# Altium schematic sheet Gecko.SchDoc
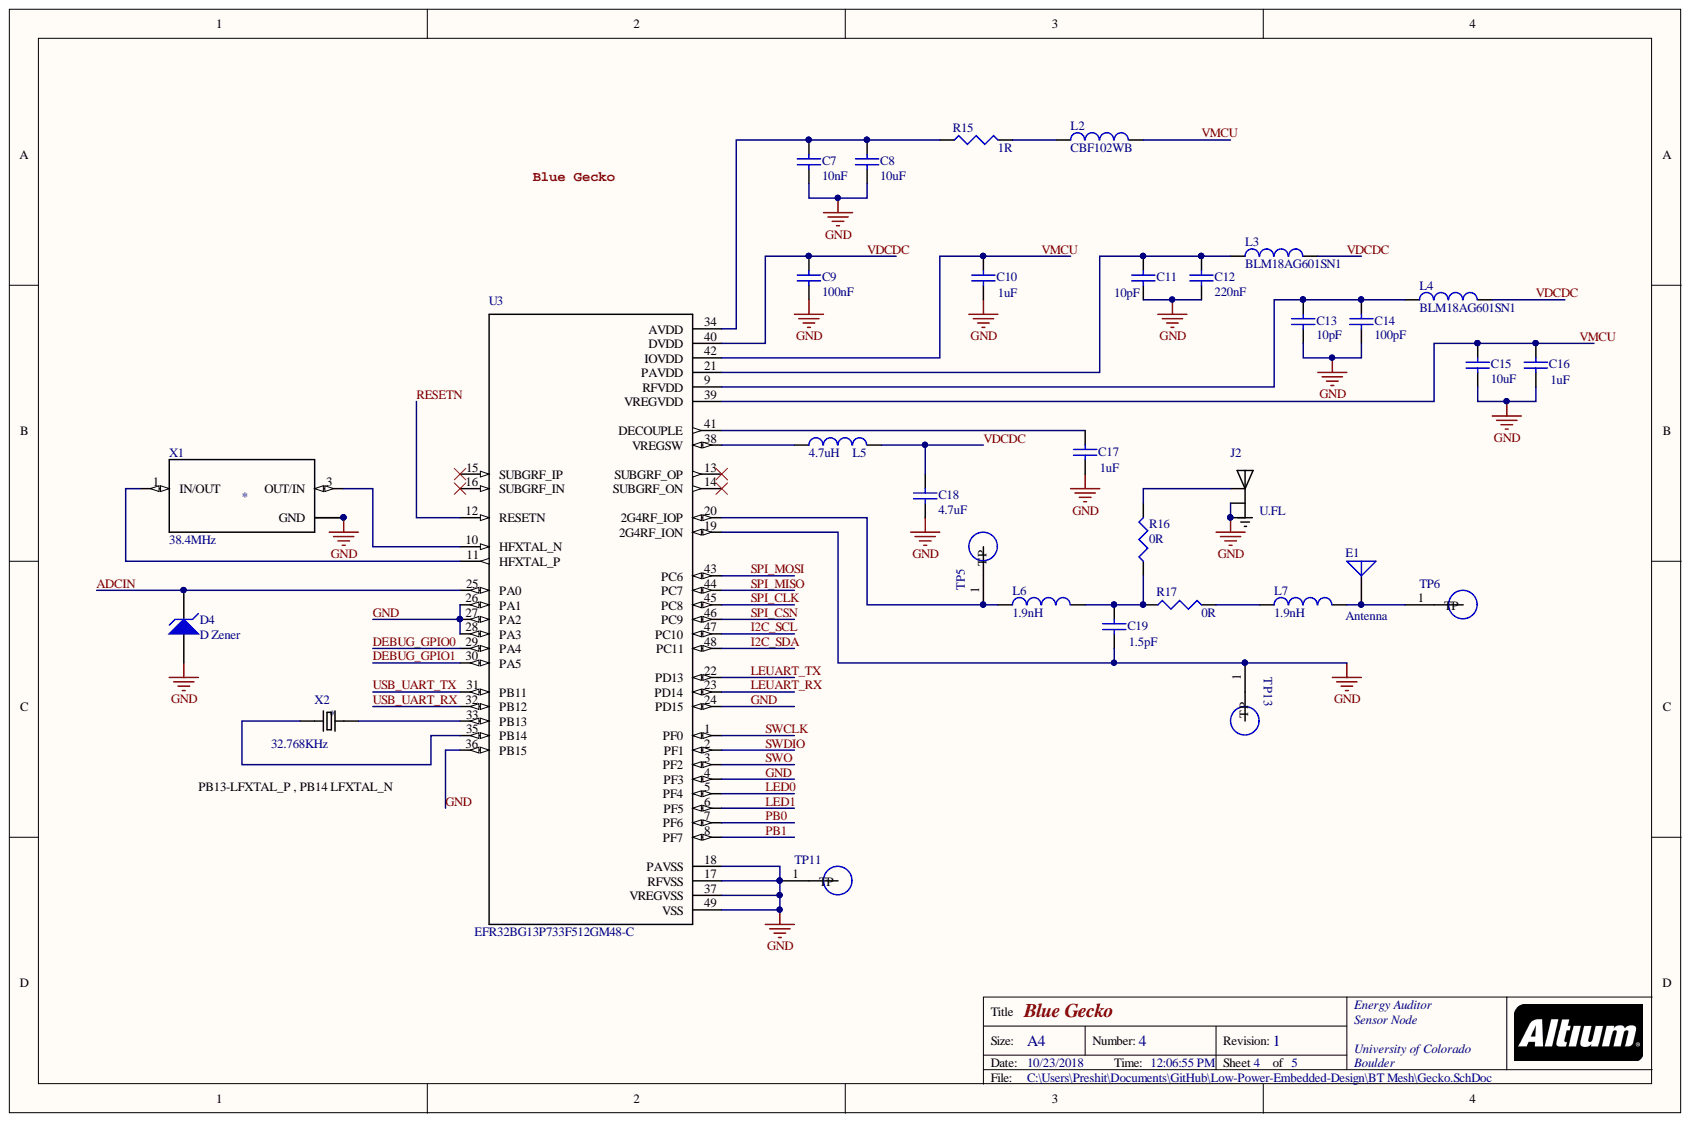
[diagram p0: Gecko.SchDoc]

## Parts
C7  10nF  [1608[0603]]
C8  10uF  [1608[0603]]
C9  100nF  [1608[0603]]
C10  1uF  [1608[0603]]
C11  10pF  [1608[0603]]
C12  220nF  [1608[0603]]
C13  10pF  [1608[0603]]
C14  100pF  [1608[0603]]
C15  10uF  [1608[0603]]
C16  1uF  [1608[0603]]
C17  1uF  [1608[0603]]
C18  4.7uF  [1608[0603]]
C19  1.5pF  [0402]
D4  D Zener  [0402]
E1  Antenna  [PIN1]
J2  U.FL  [HRS_U.FL-R-SMT-1(10)]
L2  CBF102WB  [0603]
L3  BLM18AG601SN1  [0603]
L4  BLM18AG601SN1  [0603]
L5  4.7uH  [0402-A]
L6  1.9nH  [0402-A]
L7  1.9nH  [0402-A]
R15  1R  [0402]
R16  0R  [1608[0603]]
R17  0R  [1608[0603]]
TP5  5019  [TP380X203]  (value not drawn)
TP6  5019  [TP380X203]  (value not drawn)
TP11  5019  [TP380X203]  (value not drawn)
TP13  5019  [TP380X203]  (value not drawn)
U3  EFR32BG13P733F512GM48-C  [QFN50P700X700X90-49N]
X1  38.4MHz  [XTAL_ECS-384-8-36-CKY-TR]
X2  32.768KHz  [XTAL_ECS-.327-12.5-34R-C-TR]

## Nets
ADCIN: D4.2 U3.25(PA0)
DEBUG_GPIO0: U3.29(PA4)
DEBUG_GPIO1: U3.30(PA5)
GND: C7.2 C8.2 C9.2 C10.1 C11.2 C12.2 C13.2 C14.2 C15.2 C16.1 C17.1 C18.2 C19.1 D4.1 J2.1 J2.3 TP11.1 TP13.1 U3.4(PF3) U3.17(RFVSS) U3.18(PAVSS) U3.19(2G4RF_ION) U3.24(PD15) U3.26(PA1) U3.27(PA2) U3.28(PA3) U3.36(PB15) U3.37(VREGVSS) U3.49(VSS) X1.2(GND) X1.4
I2C_SCL: U3.47(PC10)
I2C_SDA: U3.48(PC11)
LED0: U3.5(PF4)
LED1: U3.6(PF5)
LEUART_RX: U3.23(PD14)
LEUART_TX: U3.22(PD13)
PB0: U3.7(PF6)
PB1: U3.8(PF7)
RESETN: U3.12(RESETN)
SPI_CLK: U3.45(PC8)
SPI_CSN: U3.46(PC9)
SPI_MISO: U3.44(PC7)
SPI_MOSI: U3.43(PC6)
SWCLK: U3.1(PF0)
SWDIO: U3.2(PF1)
SWO: U3.3(PF2)
USB_UART_RX: U3.32(PB12)
USB_UART_TX: U3.31(PB11)
VDCDC: C9.1 C18.1 L3.2 L4.2 L5.2 U3.40(DVDD)
VMCU: C10.2 C15.1 C16.2 L2.2 U3.39(VREGVDD) U3.42(IOVDD)
NetC7_1: C7.1 C8.1 R15.1 U3.34(AVDD)
NetC11_1: C11.1 C12.1 L3.1 U3.21(PAVDD)
NetC13_1: C13.1 C14.1 L4.1 U3.9(RFVDD)
NetC17_2: C17.2 U3.41(DECOUPLE)
NetC19_2: C19.2 L6.2 R16.1 R17.1
NetE1_1: E1.1 L7.2 TP6.1
NetJ2_2: J2.2 R16.2
NetL2_1: L2.1 R15.2
NetL5_1: L5.1 U3.38(VREGSW)
NetL6_1: L6.1 TP5.1 U3.20(2G4RF_IOP)
NetL7_1: L7.1 R17.2
NetU3_10: U3.10(HFXTAL_N) X1.3(OUT/IN)
NetU3_11: U3.11(HFXTAL_P) X1.1(IN/OUT)
NetU3_33: U3.33(PB13) X2.2
NetU3_35: U3.35(PB14) X2.1

Not connected: U3 13(SUBGRF_OP) 14(SUBGRF_ON) 15(SUBGRF_IP) 16(SUBGRF_IN)

## Library data of the parts
C7 = Cap: CAP CER 10000PF 16V X7R 0603; Manufacturer: KEMET; Manufacturer Part Number: C0603S103K4RACTU; Component Kind: Standard; ComponentLink1Description: Digi-Key Link; ComponentLink1URL: https://www.digikey.com/product-detail/en/kemet/C0603S103K4RACTU/399-9135-1-ND/3522653; Digi-Key Part Number: 399-9135-1-ND; Library Name: Miscellaneous Devices.IntLib; Signal Integrity: Cap; Simulation: CAP; Value: 10nF
C8 C15 = Cap: CAP CER 10UF 10V X5R 0603; Manufacturer: Murata Electronics North America; Manufacturer Part Number: GRM188R61A106KE69D; Component Kind: Standard; ComponentLink1Description: Digi-Key Link; ComponentLink1URL: https://www.digikey.com/products/en?keywords=490-10474-1-ND; Digi-Key Part Number: 490-10474-1-ND; Library Name: Miscellaneous Devices.IntLib; Signal Integrity: Cap; Simulation: CAP; Value: 10uF
C9 C14 = Cap: CAP CER 0.1UF 16V X7R 0603; Manufacturer: AVX Corporation; Manufacturer Part Number: 0603YC104MAT4A; Component Kind: Standard; ComponentLink1Description: Digi-Key Link; Digi-Key Part Number: 478-7617-1-ND; Library Name: Miscellaneous Devices.IntLib; Signal Integrity: Cap; Simulation: CAP
  C9: ComponentLink1URL: https://www.digikey.com/products/en/capacitors/ceramic-capacitors/60?k=capacitor&k=&pkeyword=capacitor&pv2049=u0.1%C2%B5F&sf=0&FV=c0005%2C1c0002%2C380009%2C400005%2C1f140000%2Cffe0003c&quantity=&ColumnSort=0&page=1&stock=1&pageSize=25; Value: 100nF
  C14: ComponentLink1URL: https://www.digikey.com/products/en/capacitors/ceramic-capacitors/60?k=capacitor&k=&pkeyword=capacitor&pv2049=u0.1%C2%B5F&sf=0&FV=c0005%2C1c0002%2C380009%2C400005%2C440005%2C1f140000%2Cffe0003c&quantity=&ColumnSort=0&page=1&stock=1&pageSize=25; Value: 100pF
C10 C16 C17 = Cap: CAP CER 1UF 16V X7R 0603; Manufacturer: Samsung Electro-Mechanics; Manufacturer Part Number: CL10B105MO8NNWC; Component Kind: Standard; ComponentLink1Description: Digi-Key Link; ComponentLink1URL: https://www.digikey.com/product-detail/en/samsung-electro-mechanics/CL10B105MO8NNWC/1276-6524-1-ND/5961383; Digi-Key Part Number: 1276-6524-1-ND; Library Name: Miscellaneous Devices.IntLib; Signal Integrity: Cap; Simulation: CAP; Value: 1uF
C11 C13 = Cap: CAP CER 10PF 50V C0G/NP0 0603; Manufacturer: Johanson Dielectrics Inc.; Manufacturer Part Number: 500X14N100MV4T; Component Kind: Standard; ComponentLink1Description: Digi-Key Link; ComponentLink1URL: https://www.digikey.com/products/en/capacitors/ceramic-capacitors/60?k=capacitor&k=&pkeyword=capacitor&pv2049=u10pF&pv17=18&sf=0&FV=c0005%2C1c0002%2C400005%2C1f140000%2Cffe0003c&quantity=&ColumnSort=0&page=1&stock=1&pageSize=25; Digi-Key Part Number: 709-1001-1-ND; Library Name: Miscellaneous Devices.IntLib; Signal Integrity: Cap; Simulation: CAP; Value: 10pF
C12 = Cap: CAP CER 0.22UF 16V X7R 0603; Manufacturer: TDK Corporation; Manufacturer Part Number: CGA3E2X7R1C224M080AD; Component Kind: Standard; ComponentLink1Description: Digi-Key Link; ComponentLink1URL: https://www.digikey.com/product-detail/en/tdk-corporation/CGA3E2X7R1C224M080AD/445-9810-1-ND/3931737; Digi-Key Part Number: 445-9810-1-ND; Library Name: Miscellaneous Devices.IntLib; Signal Integrity: Cap; Simulation: CAP; Value: 220nF
C18 = Cap: CAP CER 4.7UF 16V X5R 0603; Manufacturer: Taiyo Yuden; Manufacturer Part Number: EMK107ABJ475MA-T; Component Kind: Standard; ComponentLink1Description: Digi-Key Link; ComponentLink1URL: https://www.digikey.com/product-detail/en/taiyo-yuden/EMK107ABJ475MA-T/587-6003-1-ND/8040666; Digi-Key Part Number: 587-6003-1-ND; Library Name: Miscellaneous Devices.IntLib; Signal Integrity: Cap; Simulation: CAP; Value: 4.7uF
C19 = Cap: CAP CER 1.5PF 50V C0G/NP0 0402; Manufacturer: Murata Electronics North America; Manufacturer Part Number: GRM1555C1H1R5WA01D; Component Kind: Standard; ComponentLink1Description: Digi-Key Link; ComponentLink1URL: https://www.digikey.com/product-detail/en/murata-electronics-north-america/GRM1555C1H1R5WA01D/490-6213-1-ND/3845410; Digi-Key Part Number: 490-6213-1-ND; Library Name: Miscellaneous Devices.IntLib; Signal Integrity: Cap; Simulation: CAP; Value: 1.5pF
D4 = D Zener: Zener Diode; Manufacturer: Texas Instruments; Manufacturer Part Number: TPD1E10B06DPYR; Component Kind: Standard; ComponentLink1Description: Digi-Key Link; ComponentLink1URL: https://www.digikey.com/product-detail/en/texas-instruments/TPD1E10B06DPYR/296-30406-1-ND/3283560; Digi-Key Part Number: 296-30406-1-ND; Library Name: Miscellaneous Devices.IntLib; Signal Integrity: D Zener; Simulation: ZENER
E1 = Antenna: Generic Antenna; Component Kind: Standard; Library Name: Miscellaneous Devices.IntLib
J2 = U.FL-R-SMT-1(10): U.FL Series 6 Ghz 50 Ohm Ultra-small SMT Coaxial Cable Receptacle; Manufacturer: Hirose; Manufacturer Part Number: U.FL-R-SMT-1_10_; Component Kind: Standard; ComponentLink1Description: Digi-Key Link; ComponentLink1URL: https://www.digikey.com/product-detail/en/hirose-electric-co-ltd/U.FL-R-SMT-1_10_/H11891CT-ND/2504612?utm_source=snapeda&utm_medium=aggregator&utm_campaign=symbol; Digi-Key Part Number: H11891CT-ND; Library Name: U.FL-R-SMT-1_10_.SchLib
L2 = Inductor: FERRITE BEAD 1 KOHM 0603 1LN; Manufacturer: Wurth Electronics Inc.; Manufacturer Part Number: 74279266; Component Kind: Standard; ComponentLink1Description: Digi-Key Link; ComponentLink1URL: https://www.digikey.com/product-detail/en/wurth-electronics-inc/74279266/732-4484-1-ND/3907313; Digi-Key Part Number: 732-4484-1-ND; Library Name: Miscellaneous Devices.IntLib; Signal Integrity: Inductor; Simulation: INDUCTOR; Value: CBF102WB
L3 L4 = Inductor: FERRITE BEAD 600 OHM 0603 1LN; Manufacturer: Murata Electronics North America; Manufacturer Part Number: BLM18AG601SN1D; Component Kind: Standard; ComponentLink1Description: Digi-Key Link; ComponentLink1URL: https://www.digikey.com/products/en/filters/ferrite-beads-and-chips/841?k=BLM18AG601SN1&k=&pkeyword=BLM18AG601SN1&pv7=2&sf=0&quantity=&ColumnSort=0&page=1&pageSize=25; Digi-Key Part Number: 490-1014-1-ND; Library Name: Miscellaneous Devices.IntLib; Signal Integrity: Inductor; Simulation: INDUCTOR; Value: BLM18AG601SN1
L5 = Inductor: FIXED IND 4.7UH 500MA 500 MOHM; Manufacturer: Abracon LLC; Manufacturer Part Number: AIML-0603HC-4R7M-T; Component Kind: Standard; ComponentLink1Description: Digi-Key Link; ComponentLink1URL: https://www.digikey.com/product-detail/en/abracon-llc/AIML-0603HC-4R7M-T/535-12599-1-ND/4864742; Digi-Key Part Number: 535-12599-1-ND; Library Name: Miscellaneous Devices.IntLib; Signal Integrity: Inductor; Simulation: INDUCTOR; Value: 4.7uH
L6 L7 = Inductor: FIXED IND 1.9NH 220MA 300 MOHM; Manufacturer: Murata Electronics North America; Manufacturer Part Number: LQP15MN1N9W02D; Component Kind: Standard; ComponentLink1Description: Digi-Key Link; ComponentLink1URL: https://www.digikey.com/product-detail/en/murata-electronics-north-america/LQP15MN1N9W02D/490-11739-1-ND/5333579; Digi-Key Part Number: 490-11739-1-ND; Library Name: Miscellaneous Devices.IntLib; Signal Integrity: Inductor; Simulation: INDUCTOR; Value: 1.9nH
R15 = Res3: RES SMD 1 OHM 1% 1/16W 0402; Manufacturer: Yageo; Manufacturer Part Number: RC0402FR-071RL; Component Kind: Standard; ComponentLink1Description: Digi-Key Link; ComponentLink1URL: https://www.digikey.com/products/en/resistors/chip-resistor-surface-mount/52?k=resistor&k=&pkeyword=resistor&pv3=1&sf=0&FV=1c0002%2C1f140000%2Cmu1+Ohms%7C2085%2Cffe00034%2C80001&quantity=&ColumnSort=0&page=1&stock=1&pageSize=25; Digi-Key Part Number: 311-1.00LRCT-ND; Library Name: Miscellaneous Devices.IntLib; Signal Integrity: Res; Simulation: RESISTOR; Value: 1R
R16 R17 = Res3: RES 0 OHM JUMPER 1/8W 0603; Manufacturer: Vishay Beyschlag; Manufacturer Part Number: MCT0603MZ0000ZP500; Component Kind: Standard; ComponentLink1Description: Digi-Key Link; ComponentLink1URL: https://www.digikey.com/product-detail/en/vishay-beyschlag/MCT0603MZ0000ZP500/749-1745-1-ND/7348053; Digi-Key Part Number: 749-1745-1-ND; Library Name: Miscellaneous Devices.IntLib; Signal Integrity: Res; Simulation: RESISTOR; Value: 0R
TP5 TP6 TP11 TP13 = 5019: Phosphor Bronze Contact Miniature Silver Plated Surface Mount Test Points; Manufacturer: Keystone; Component Kind: Standard; ComponentLink1Description: Digi-Key Link; ComponentLink1URL: https://www.digikey.com/product-detail/en/keystone-electronics/5019/36-5019CT-ND/3907344?utm_source=snapeda&utm_medium=aggregator&utm_campaign=symbol; Digi-Key Part Number: 36-5019CT-ND; Library Name: 5019.IntLib; Manufacturer Part Number: 5019
U3 = EFR32BG13P733F512GM48-B: EFR32BG13 Blue Gecko BluetoothÂ® Low Energy SoC; Manufacturer: Silicon Labs; Component Kind: Standard; ComponentLink1Description: Digi-Key Link; ComponentLink1URL: https://www.digikey.com/product-detail/en/silicon-labs/EFR32BG13P733F512GM48-C/336-4110-ND/7599813; Digi-Key Part Number: 336-4110-ND; Library Name: EFR32BG13P733F512GM48-B.IntLib; Manufacturer Part Number: EFR32BG13P733F512GM48-C
X1 = ECS-384-8-36-CKY-TR: Crystal 38.4mhz 8pf Smd; Manufacturer: ECS Inc.; Component Kind: Standard; ComponentLink1Description: Digi-Key Link; ComponentLink1URL: https://www.digikey.com/product-detail/en/ecs-inc/ECS-384-8-36-CKY-TR/XC2538CT-ND/8023473?utm_source=snapeda&utm_medium=aggregator&utm_campaign=symbol; Digi-Key Part Number: XC2538CT-ND; Library Name: ECS-384-8-36-CKY-TR.IntLib; Manufacturer Part Number: ECS-384-8-36-CKY-TR
X2 = ECS-.327-12.5-34R-C-TR: CRYSTAL 32.768KHZ 12.5PF SMD; Manufacturer: ECS International; Component Kind: Standard; ComponentLink1Description: Digi-Key Link; ComponentLink1URL: https://www.digikey.com/products/en?keywords=XC2127CT-ND; Digi-Key Part Number: XC2127CT-ND; Library Name: ECS-.327-12.5-34R-C-TR.SchLib; Manufacturer Part Number: ECS-.327-12.5-34R-C-TR
